# Altium schematic sheet MCU_IMU.SchDoc
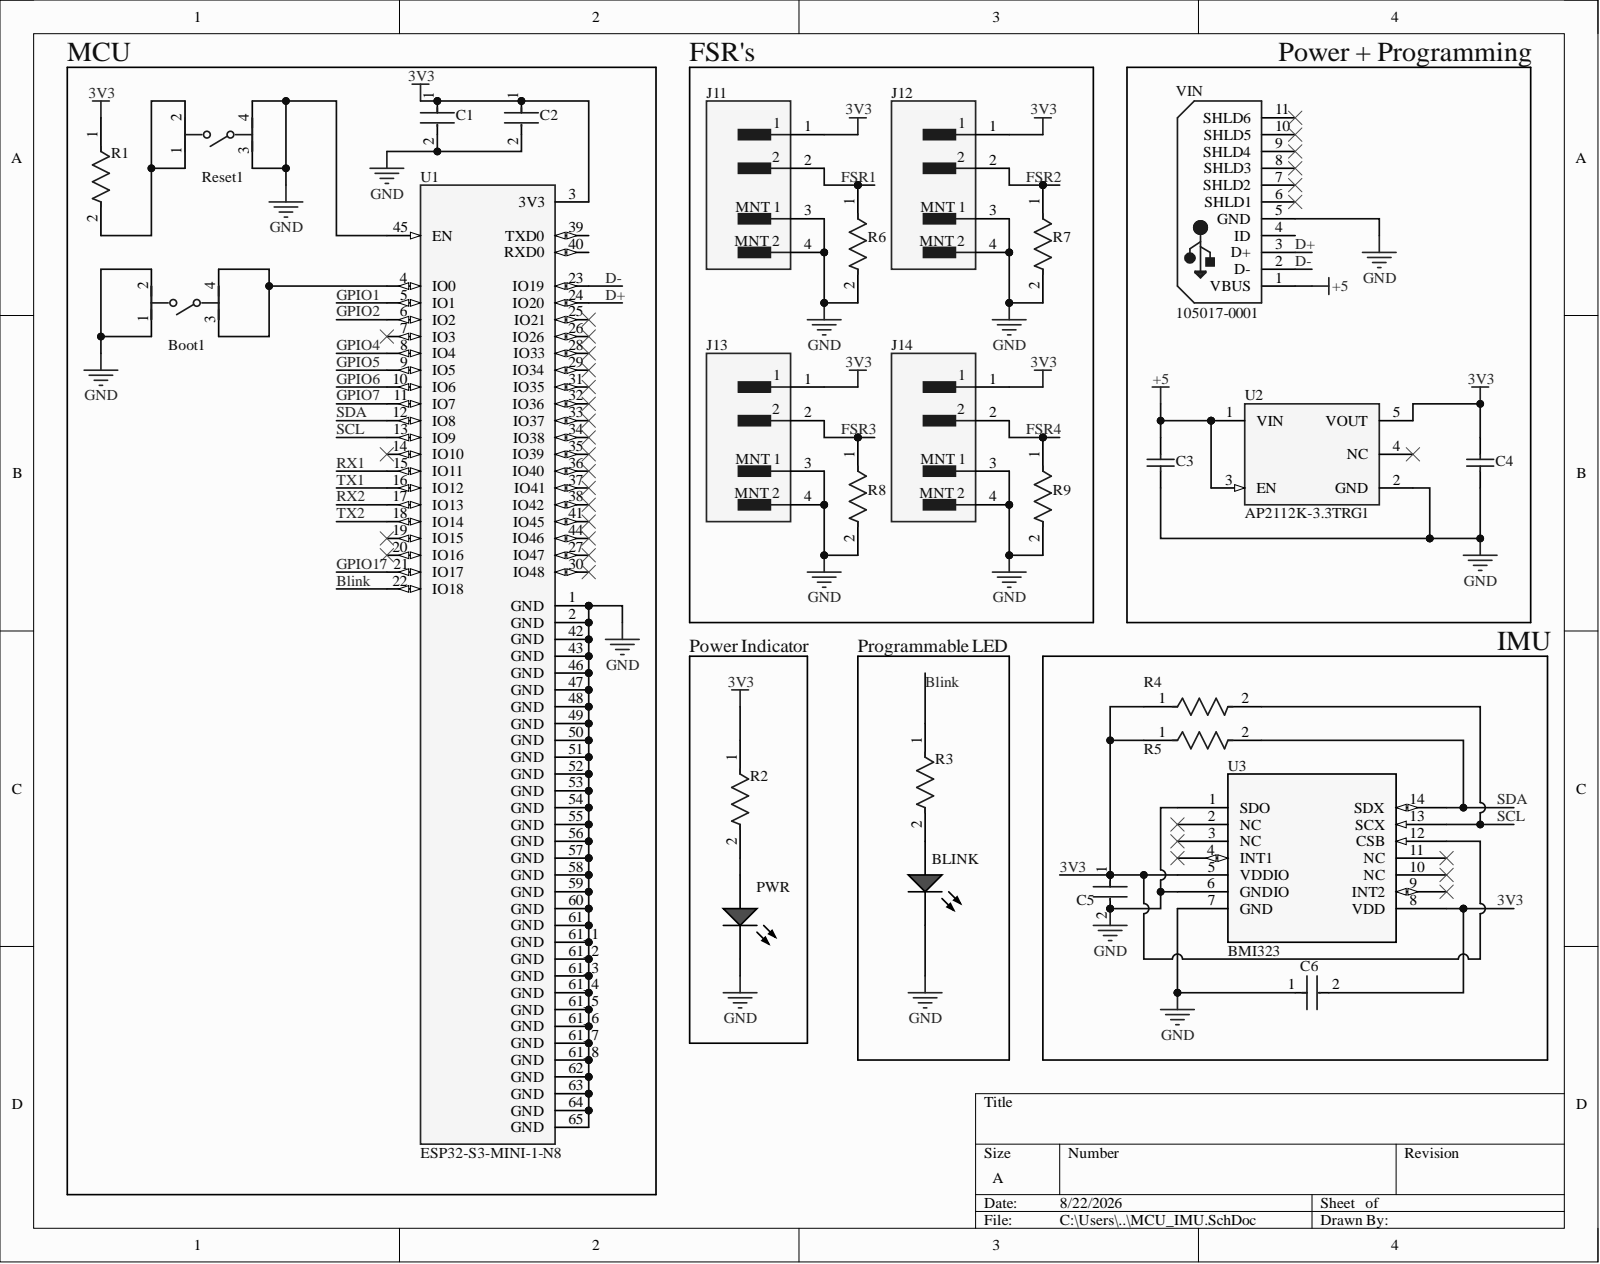
[diagram p0: MCU_IMU.SchDoc]

## Parts
BLINK  SML-LX1206IC-TR  [LUMX-SML-LX1206IC_V]  (value not drawn)
Boot1  PTS810SJM250SMTRLFS  [FP-PTS810SJM250SMTRLFS-MFG]  (value not drawn)
C1  CC0805KRX7R9BB104  [FP-CC0805-0_85-MFG]  (value not drawn)
C2  CC0805KRX7R9BB104  [FP-CC0805-0_85-MFG]  (value not drawn)
C3  C0805C105K5RACTU  [CAPC2013X140X50ML20T25]  (value not drawn)
C4  C0805C105K5RACTU  [CAPC2013X140X50ML20T25]  (value not drawn)
C5  CC0805KRX7R9BB104  [FP-CC0805-0_85-MFG]  (value not drawn)
C6  CC0805KRX7R9BB104  [FP-CC0805-0_85-MFG]  (value not drawn)
J11  BM02B-SRSS-TBT(LF)(SN)  [FP-BM02B-SRSS-TBT_LF_SN-MFG]  (value not drawn)
J12  BM02B-SRSS-TBT(LF)(SN)  [FP-BM02B-SRSS-TBT_LF_SN-MFG]  (value not drawn)
J13  BM02B-SRSS-TBT(LF)(SN)  [FP-BM02B-SRSS-TBT_LF_SN-MFG]  (value not drawn)
J14  BM02B-SRSS-TBT(LF)(SN)  [FP-BM02B-SRSS-TBT_LF_SN-MFG]  (value not drawn)
PWR  SML-LX1206IC-TR  [LUMX-SML-LX1206IC_V]  (value not drawn)
R1  CRCW08054K70FKEA  [FP-CRCW0805-e3-IPC_B]  (value not drawn)
R2  CRCW08054K70FKEA  [FP-CRCW0805-e3-IPC_B]  (value not drawn)
R3  CRCW08054K70FKEA  [FP-CRCW0805-e3-IPC_B]  (value not drawn)
R4  CRCW08054K70FKEA  [FP-CRCW0805-e3-IPC_B]  (value not drawn)
R5  CRCW08054K70FKEA  [FP-CRCW0805-e3-IPC_B]  (value not drawn)
R6  RC0603FR-0710KL  [FP-RC0603-0_55-IPC_C]  (value not drawn)
R7  RC0603FR-0710KL  [FP-RC0603-0_55-IPC_C]  (value not drawn)
R8  RC0603FR-0710KL  [FP-RC0603-0_55-IPC_C]  (value not drawn)
R9  RC0603FR-0710KL  [FP-RC0603-0_55-IPC_C]  (value not drawn)
Reset1  PTS810SJM250SMTRLFS  [FP-PTS810SJM250SMTRLFS-MFG]  (value not drawn)
U1  ESP32-S3-MINI-1-N8  [XCVR_ESP32-S3-MINI-1-N8]
U2  AP2112K-3.3TRG1  [FP-SOT25-IPC_A]
U3  BMI323  [BMI323_BOS]
VIN  105017-0001  [USB-MICRO-B_V]

## Nets
+5: C3.2 U2.1(VIN) U2.3(EN) VIN.1(VBUS)
GND: BLINK.2 Boot1.1 Boot1.2 C1.2 C2.2 C3.1 C4.1 C5.2 C6.1 J11.3 J11.4 J12.3 J12.4 J13.3 J13.4 J14.3 J14.4 PWR.2 R6.2 R7.2 R8.2 R9.2 Reset1.3 Reset1.4 U1.1(GND) U1.2(GND) U1.42(GND) U1.43(GND) U1.45(EN) U1.46(GND) U1.47(GND) U1.48(GND) U1.49(GND) U1.50(GND) U1.51(GND) U1.52(GND) U1.53(GND) U1.54(GND) U1.55(GND) U1.56(GND) U1.57(GND) U1.58(GND) U1.59(GND) U1.60(GND) U1.61(GND) U1.61_1(GND) U1.61_2(GND) U1.61_3(GND) U1.61_4(GND) U1.61_5(GND) U1.61_6(GND) U1.61_7(GND) U1.61_8(GND) U1.62(GND) U1.63(GND) U1.64(GND) U1.65(GND) U2.2(GND) U3.1(SDO) U3.6(GNDIO) U3.7(GND) VIN.5(GND)
3V3: C1.1 C2.1 C4.2 C5.1 C6.2 J11.1 J12.1 J13.1 J14.1 R1.1 R2.1 R4.1 R5.1 U1.3(3V3) U2.5(VOUT) U3.5(VDDIO) U3.8(VDD) U3.12(CSB)
Blink: R3.1 U1.22(IO18)
D+: U1.24(IO20) VIN.3(D+)
D-: U1.23(IO19) VIN.2(D-)
FSR1: J11.2 R6.1
FSR2: J12.2 R7.1
FSR3: J13.2 R8.1
FSR4: J14.2 R9.1
GPIO1: U1.5(IO1)
GPIO2: U1.6(IO2)
GPIO4: U1.8(IO4)
GPIO5: U1.9(IO5)
GPIO6: U1.10(IO6)
GPIO7: U1.11(IO7)
GPIO17: U1.21(IO17)
RX1: U1.15(IO11)
RX2: U1.17(IO13)
SCL: R4.2 U1.13(IO9) U3.13(SCX)
SDA: R5.2 U1.12(IO8) U3.14(SDX)
TX1: U1.16(IO12)
TX2: U1.18(IO14)
NetBLINK_1: BLINK.1 R3.2
NetBoot1_3: Boot1.3 Boot1.4 U1.4(IO0)
NetPWR_1: PWR.1 R2.2
NetR1_2: R1.2 Reset1.1 Reset1.2

Not connected: U1 7(IO3) 14(IO10) 19(IO15) 20(IO16) 25(IO21) 26(IO26) 27(IO47) 28(IO33) 29(IO34) 30(IO48) 31(IO35) 32(IO36) 33(IO37) 34(IO38) 35(IO39) 36(IO40) 37(IO41) 38(IO42) 39(TXD0) 40(RXD0) 41(IO45) 44(IO46); U2 4(NC); U3 2(NC) 3(NC) 4(INT1) 9(INT2) 10(NC) 11(NC); VIN 4(ID) 6(SHLD1) 7(SHLD2) 8(SHLD3) 9(SHLD4) 10(SHLD5) 11(SHLD6)

## Library data of the parts
BLINK PWR = CMP-2000-07123-1: LED Red, 65 mW, -40 to 85 degC, 2-Pin SMD, RoHS, Tape and Reel; Manufacturer: Lumex; Intensity: 6mcd; LED Material: GaAsP; Lens Appearance: ColorlessNonDiffused; Number of LEDs: 1; Peak Wavelength: 635nm; Pin_Count: 2; Reverse Voltage: 5(Min)V; Viewing Angle: 160; Color: Red; Component Kind: Standard; Datasheet URL: http://www.lumex.com/content/files/ProductAttachment/SML-LX1206IC-TR.pdf; Datasheet Version: Rev. J, 08/2012; Dimension: 3.2x1.6x1.1mm; Dominant Wavelength: N/A; ECCN: EAR99; Family: SML-LX1206IC; HTS: 8541402000; Lens Dimensions: 2x1.6x0.5mm; Library Name: Altium Content Vault; Manufacturer URL: http://www.lumexinstruments.com/; Mounting: SurfaceMount; Mounting Technology: SurfaceMount; Operating Temperature: -25to85C; Package Description: 2-Pin Surface Mount Device, Body 3.2 x 1.5 mm; Package Reference: SML-LX1206IC; Packaging: TapeandReel; Part No: SML-LX1206IC-TR; RoHS: Unknown; Supplier: Lumex; Supplier Standard Pack: 3,000; Supplier_Package: SMD; Type: Uni-Color
Boot1 Reset1 = CMP-10040-000001-2: SWITCH TACTILE SPST-NO 0.05A 16V; Actuator Type: Standard; Current Rating: 50mA; Illumination Type: Non-Illuminated; Mechanical Life: 150000Cycles; Operating Force: 160gf; Switch Function: Off-Mom; Switch Travel: 0.15mm; Throw Configuration: SPST; Case/Package: SMD/SMT; Color: Black; Component Kind: Standard; Contact Plating: Silver; Depth: 4.6mm; Lead Free: Lead Free; Length: 3.2mm; Library Name: Altium Content Vault; Max Current Rating: 50mA; Max Operating Temperature: 85°C; Min Operating Temperature: -40°C; Mount: Surface Mount; Orientation: Straight; Package Quantity: 2900; Packaging: Tape and Reel; RoHS Compliant: Yes; Termination: J-Bend; Voltage Rating (DC): 16V
C1 C2 C5 C6 = CMP-2100-03606-3: CAP CER 0.1UF 50V X7R 0805; Manufacturer: Yageo; Capacitance: 100 nF; Material: Ceramic; Tolerance: 0.1; Value: 100 nF; Automotive: No; Category: Cap; Component Kind: Standard; ComponentLink2Description: Datasheet; ComponentLink2URL: http://www.yageo.com/documents/recent/UPY-GPHC_Y5V_6.3V-to-50V_8.pdf; Device Class L1: Passive Components; Device Class L2: Capacitors; Device Class L3: Ceramic Capacitors; Digikey Description: CAP CER 0.1UF 50V X7R 0805; Footprint Url: http://www.yageo.com/exep/pages/download/literatures/UPY-C_GEN_24.pdf; Height: 0.95mm; Ipc Land Pattern Name: CAPC200125X85; Lead Free: Yes; Library Name: Altium Content Vault; Manufacturer Part Number: CC0805KRX7R9BB104; Mouser Description: Multilayer Ceramic Capacitors MLCC - SMD/SMT 100nF 50V X7R 10%; Octopart: https://octopart.com/cc0805krx7r9bb104-yageo-192993; Package: CC0805-0.85; RoHS: TRUE; Temperature: -30°C to +85°C; Temperature Characteristic: X7R; Temperature Range High: +85°C; Temperature Range Low: -30°C; Voltage Rating: 50 V
C3 C4 = CMP-2007-03236-1: Manufacturer: Kemet; Component Kind: Standard; Library Name: Altium Content Vault; Part Number: C0805C105K5RACTU
J11-J14 = CMP-17439-000179-1: CONN WIRE HDR 2POS 1MM STSMD; Connector Type: Header; Contact Resistance: 20mR; Mated Stacking Heights: 4.9mm; Max Current Rating: 1A; Max Voltage Rating (AC): 50V; Number of Positions: 2; Pitch: 0.039inch; Color: Natural; Component Kind: Standard; Contact Finish - Mating: TIN; Contact Material: Copper Alloy; Contact Plating: Tin; Contact Shape: Square; Contact Type: Male Pin; Depth: 3.6mm; Fastening Type: Pull; Flammability Rating: UL94 V-0; Housing Color: Natural; Housing Material: Polyamide; Insulation Color: Natural; Insulation Material: Nylon; Lead Free: Lead Free; Length: 4mm; Library Name: Altium Content Vault; Max Operating Temperature: 85°C; Max Voltage Rating (DC): 50V; Min Operating Temperature: -25°C; Mount: Surface Mount; Number of Rows: 1; Orientation: Straight; Package Quantity: 1500; Packaging: Tape and Reel; Series: SR; Termination: Solder; Voltage Rating: 50V
R1-R5 = CMP-2100-03615-2: RES Thick Film, 4.7kΩ, 1%, 0.125W, 100ppm/°C, 0805; Max Power Dissipation: 125mW; Resistance: 4.7kR; Voltage Rating: 150V; Case Code (Imperial): 0805; Case Code (Metric): 2012; Case/Package: 0805; Component Kind: Standard; Composition: Thick Film; Contact Plating: Tin; Depth: 1.25mm; Features: Automotive AEC-Q200; Height: 0.45mm; Lead Free: Lead Free; Length: 2mm; Library Name: Altium Content Vault; Max Operating Temperature: 155°C; Military Standard: Not; Min Operating Temperature: -55°C; Number of Terminations: 2; Package Quantity: 10; Packaging: Tape and Reel; Power Rating: 125mW; Radiation Hardening: No; Temperature Coefficient: 100ppm/°C; Termination: SMD/SMT; Tolerance: 1%; Voltage Rating (DC): 150V; Weight: 0.000194oz; Width: 1.25mm
R6-R9 = CMP-2100-03664-2: RES 10K OHM 1% 1/10W 0603; Max Power Dissipation: 100mW; Resistance: 10kR; Temperature Coefficient: 100ppm/°C; Voltage Rating: 75V; Voltage Rating (DC): 50V; Case Code (Imperial): 0603; Case Code (Metric): 1608; Case/Package: 0603; Component Kind: Standard; Construction: Rectangular; Depth: 0.8mm; Features: Moisture Resistant; Height: 0.45mm; Lead Free: Lead Free; Lead Pitch: 0.3mm; Length: 1.6mm; Library Name: Altium Content Vault; Max Operating Temperature: 155°C; Military Standard: Not; Min Operating Temperature: -55°C; Number of Pins: 2; Number of Terminations: 2; Packaging: Tape and Reel; Power Rating: 100mW; Resistance Tolerance: 1%; Resistor Type: Thick Film; RoHS Compliant: Yes; Series: RC; Size Code: 0603; Termination: SMD/SMT; Tolerance: 1%; Weight: 7.1E-05oz; Width: 0.8mm
U1 = CMP-025-000000-2: MF: {'manufacturer': u'Espressif Systems'}; Component Kind: Standard; Component Type: Standard; Design Item ID: ESP32-S3-MINI-1-N8; MP: ESP32-S3-MINI-1-N8; Package: None; Pin Count: 73; Price: None; Source: ESP32-S3-MINI-1-N8.SchLib; Library Name: Bryan Heddle
U2 = CMP-12756-000035-1: IC REG LINEAR 3.3V 600MA SOT25; Accuracy: 1.5%; Dropout Voltage: 250mV; Max Input Voltage: 6V; Min Input Voltage: 2.5V; Number of Outputs: 1; Output Type: Fixed; Polarity: Positive; Quiescent Current: 55uA; Case/Package: SOT-23-5; Component Kind: Standard; Height: 1.3mm; Lead Free: Lead Free; Length: 3.1mm; Library Name: Altium Content Vault; Max Operating Temperature: 85°C; Max Output Current: 600mA; Min Operating Temperature: -40°C; Mount: Surface Mount; Number of Pins: 5; Number of Regulators: 1; Output Current: 600mA; Output Voltage: 3.3V; Package Quantity: 1; Packaging: Tape and Reel; Width: 1.7mm
U3 = BMI323: No Description Available; Manufacturer_Name: Bosch Sensortec; Component Kind: Standard; Library Name: AI_Project_Library.SchLib; Manufacturer_Part_Number: BMI323; RefDes: RefDes; Type: Type; Copyright: Copyright (C) 2025 Ultra Librarian. All rights reserved.
VIN = CMP-2000-05827-1: Micro-USB B Receptacle, Right Angle, Bottom Mount, Surface Mount, with Solder Tabs, -30 to 85 degC, 5-Pin USB, RoHS, Tape and Reel; Manufacturer: Molex; 3D Model: 105017-0001.stp; Catalog Drawings: 1050170001; Circuits: 5; Connector Type: USB-microB; Featured Product: Micro-USBConnectorsUSBConnectors; Mating Products: WM17145-ND-CABLEMICROUSBBTOSTDA1.0MWM17147-ND-CABLEMICROUSBBTOSTDA2.0MWM17146-ND-CABLEMICROUSBBTOSTDA1.5M; Online Catalog: Molex-MicroUSB; Other Names: WM1399DKR; Package Version: Rev. F, 12/2012; PCN Assembly/Origin: ResinSupplier21/Oct/2015; Voltage - Max: 30V; Category: Connectors,Interconnects; Component Kind: Standard; Datasheet URL: http://www.molex.com/elqNow/elqRedir.htm?ref=http://www.molex.com/webdocs/datasheets/pdf/en-us/1050170001_IO_CONNECTORS.pdf; Datasheet Version: 03/2016; Family: USB,DVI,HDMIConnectors; Features: Shielded,SolderRetention; Gender: Receptacle; Ingress Protection: -; Library Name: Altium Content Vault; Manufacturer URL: http://www.molex.com/; Mounting Technology: SurfaceMount; Mounting Type: BoardCutout,SurfaceMount,RightAngle; Number of Contacts: 5; Package Description: 5-Leads USB, Micro B, Body 7.5 x 5 mm, Pitch 0.65 mm; Package Reference: MICRO-B; Package URL: http://www.molex.com/pdm_docs/sd/1050170001_sd.pdf; Packaging: Digi-Reel®; RoHS Information: 1050170001CertofCompliance; Series: -; Specifications: -; Standard Package: 1
